# Altium schematic sheet SoC.SchDoc(SoC)
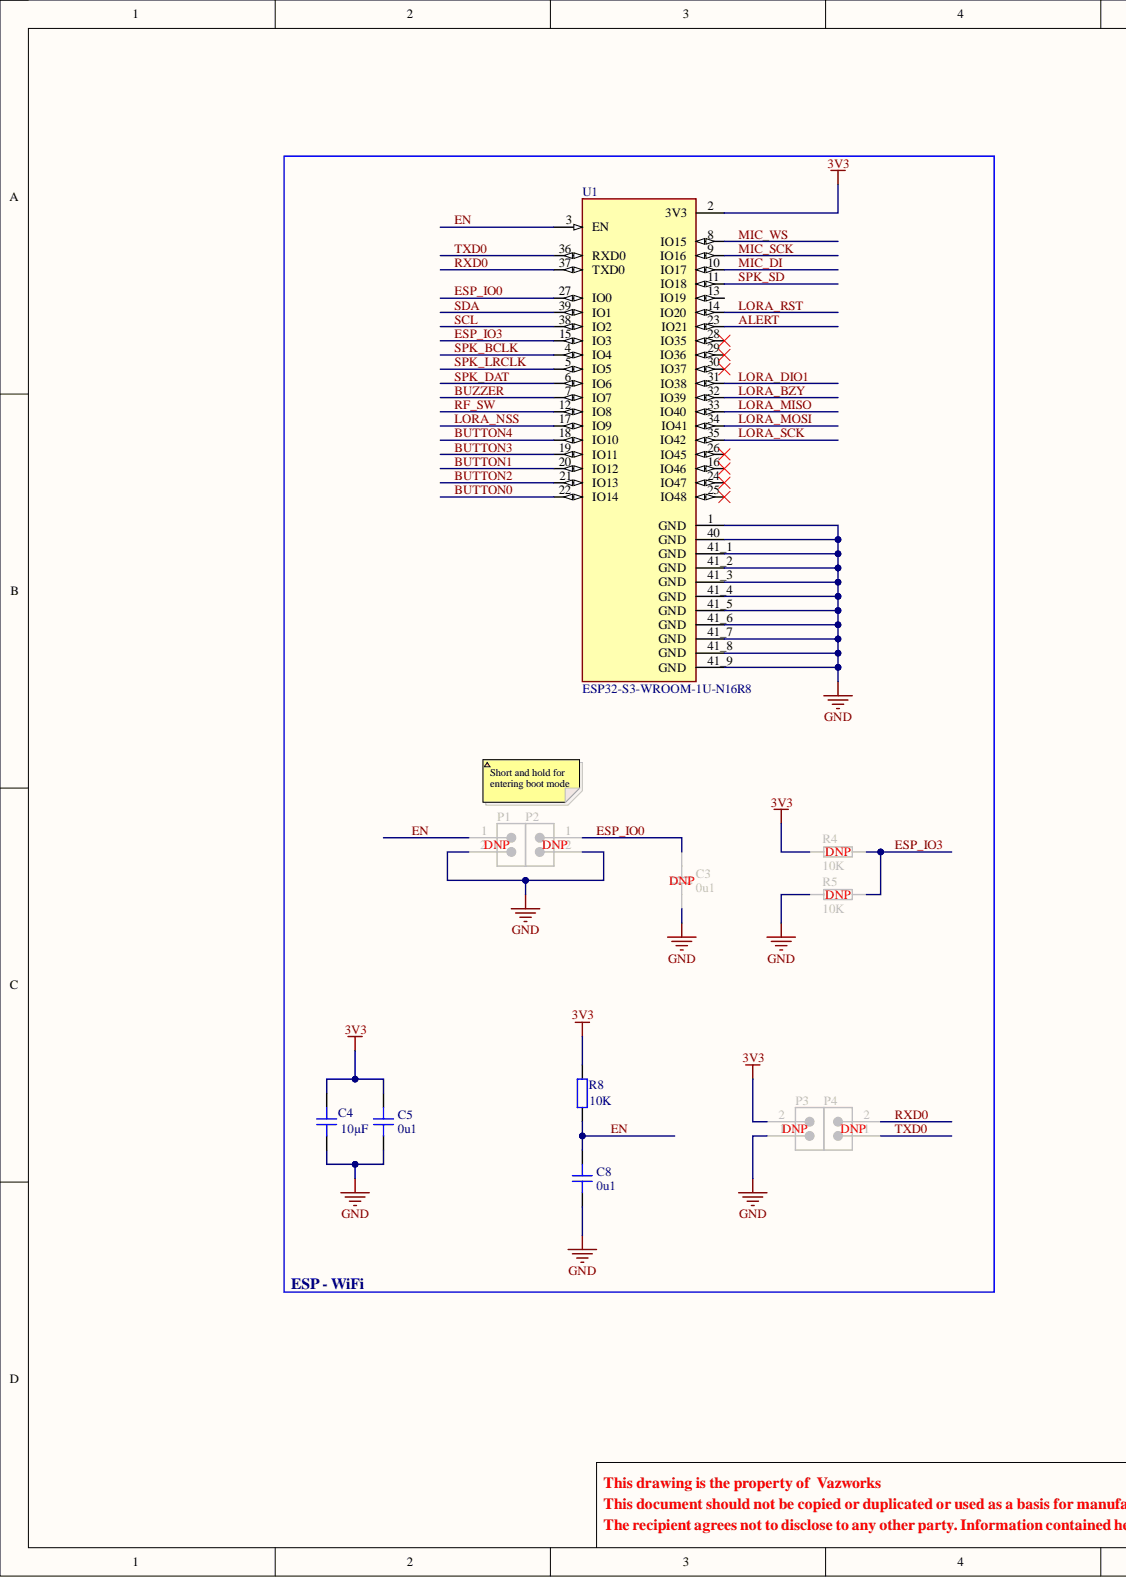
[diagram p0: SoC.SchDoc, left]
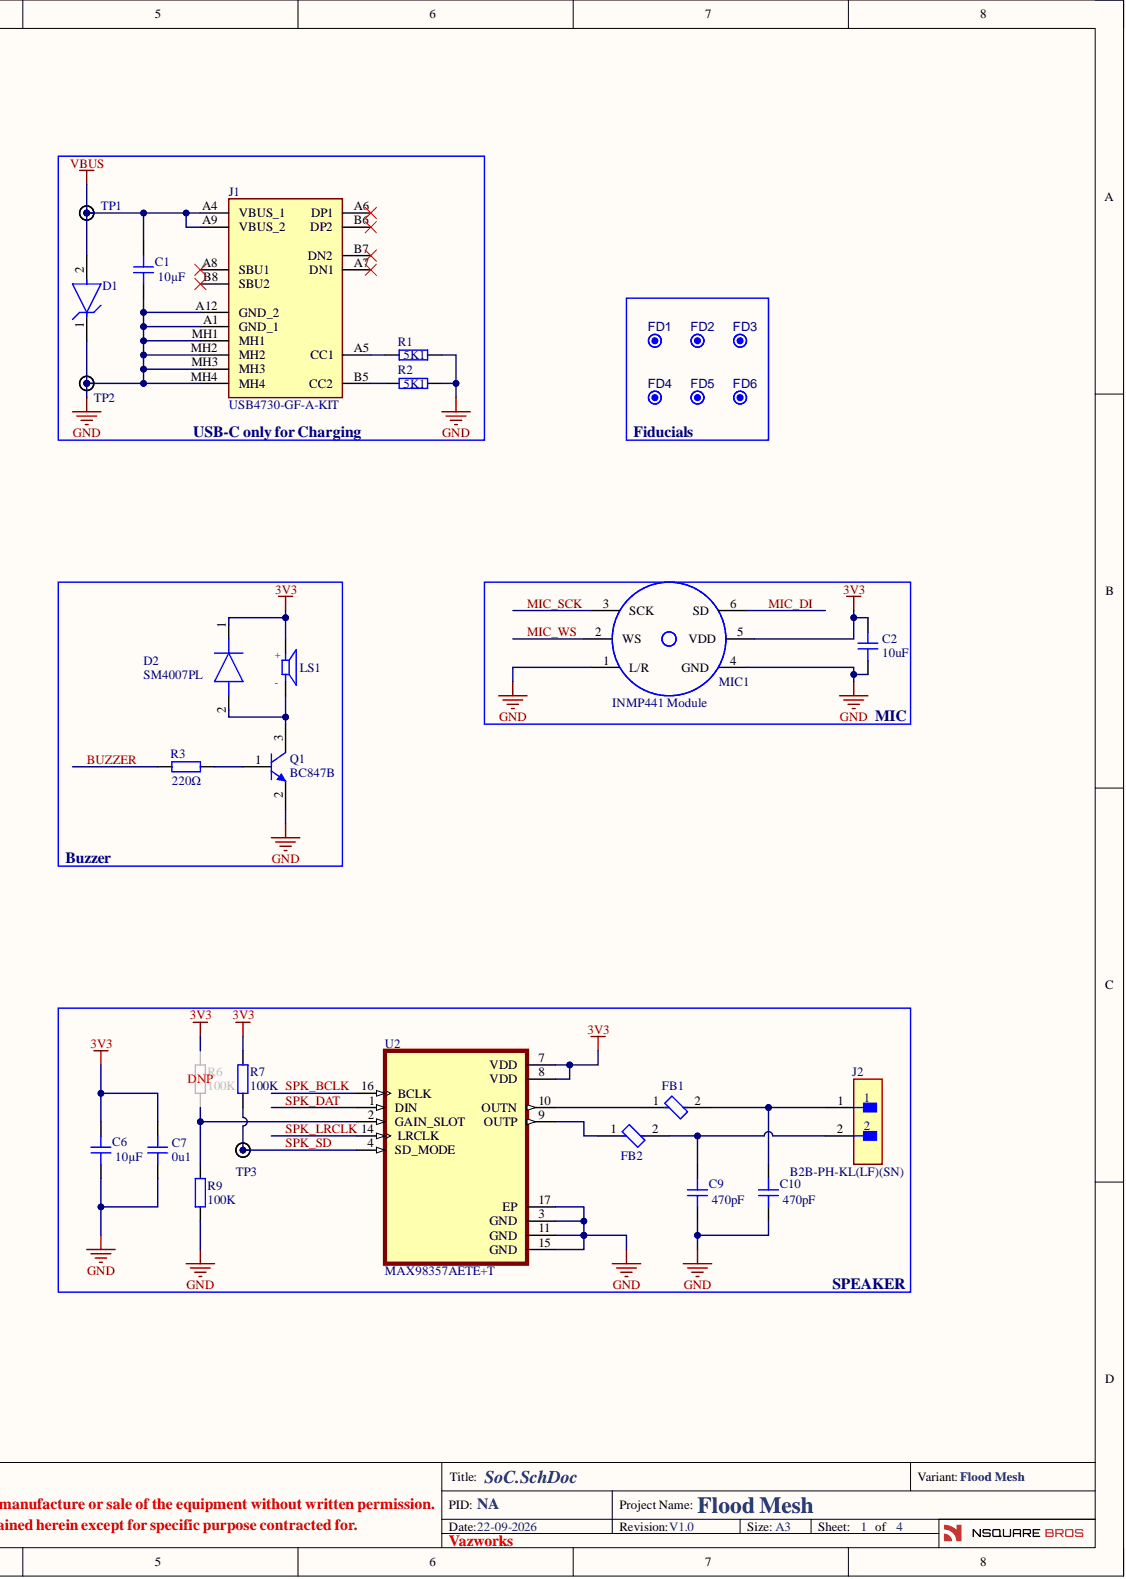
[diagram p1: SoC.SchDoc, right]

## Parts
C1  value 10µF  [CAP_0603]  (hidden comment: CL10A106KP8NNNC)
C2  value 10uF  [CAP_0603]  (hidden comment: GRM188R60J106ME47D)
C3  value 0u1  [CAP_0402]  (hidden comment: CC0402JRX7R8BB104)
C4  value 10µF  [CAP_0603]  (hidden comment: CL10A106KP8NNNC)
C5  value 0u1  [CAP_0402]  (hidden comment: CC0402JRX7R8BB104)
C6  value 10µF  [CAP_0603]  (hidden comment: CL10A106KP8NNNC)
C7  value 0u1  [CAP_0402]  (hidden comment: CC0402JRX7R8BB104)
C8  value 0u1  [CAP_0402]  (hidden comment: CC0402JRX7R8BB104)
C9  value 470pF  [CAP_0603]  (hidden comment: C0603C471J5RACAUTO)
C10  value 470pF  [CAP_0603]  (hidden comment: C0603C471J5RACAUTO)
D1  ESDA7P60-1U1M  [FP-1610-MFG]  (value not drawn)
D2  SM4007PL  [SODFL3718X125N]
FB1  MPZ2012S300ATD25  [FP-MPZ2012-IPC_B]  (value not drawn)
FB2  MPZ2012S300ATD25  [FP-MPZ2012-IPC_B]  (value not drawn)
FD1  FID1X2R  [FID1X2R]  (value not drawn)  (no BOM)
FD2  FID1X2R  [FID1X2R]  (value not drawn)  (no BOM)
FD3  FID1X2R  [FID1X2R]  (value not drawn)  (no BOM)
FD4  FID1X2R  [FID1X2R]  (value not drawn)  (no BOM)
FD5  FID1X2R  [FID1X2R]  (value not drawn)  (no BOM)
FD6  FID1X2R  [FID1X2R]  (value not drawn)  (no BOM)
J1  USB4730-GF-A-KIT  [USB4730GFAKIT]
J2  B2B-PH-KL(LF)(SN)  [FP-B2B-PH-KL_LF_SN-MFG]
LS1  CMT-0904-85T  [XDCR_CMT-0904-85T]  (value not drawn)
MIC1  INMP441 Module  [INMP441]
P1  62000211121  [62000211121]  (value not drawn)
P2  62000211121  [62000211121]  (value not drawn)
P3  62000211121  [62000211121]  (value not drawn)
P4  62000211121  [62000211121]  (value not drawn)
Q1  BC847B  [INF-SOT23_M]
R1  value 5K1  [RES_0603]  (hidden comment: AC0603FR-7W5K1L)
R2  value 5K1  [RES_0603]  (hidden comment: AC0603FR-7W5K1L)
R3  value 220Ω  [RES_0402]  (hidden comment: 0402WGF2200TCE)
R4  value 10K  [RES_0402]  (hidden comment: AC0402FR-7W10KL)
R5  value 10K  [RES_0402]  (hidden comment: AC0402FR-7W10KL)
R6  value 100K  [RES_0402]  (hidden comment: CRCW0402100KFKEDHP)
R7  value 100K  [RES_0402]  (hidden comment: CRCW0402100KFKEDHP)
R8  value 10K  [RES_0402]  (hidden comment: AC0402FR-7W10KL)
R9  value 100K  [RES_0402]  (hidden comment: CRCW0402100KFKEDHP)
TP1  TP_NOBOM  [PAD_RND_1MM]  (value not drawn)  (no BOM)
TP2  TP_NOBOM  [PAD_RND_1MM]  (value not drawn)  (no BOM)
TP3  TP_NOBOM  [PAD_RND_1MM]  (value not drawn)  (no BOM)
U1  ESP32-S3-WROOM-1U-N16R8  [XCVR_ESP32-S3-WROOM-1U-N16R8]
U2  MAX98357AETE+T  [QFN50P300X300X80-17N]

## Nets
3V3: C2.2 C4.2 C5.2 C6.2 C7.2 D2.1 LS1.P MIC1.5(VDD) P3.2 R4.1 R6.2 R7.2 R8.1 U1.2(3V3) U2.7(VDD) U2.8(VDD)
GND: C1.1 C2.1 C3.1 C4.1 C5.1 C6.1 C7.1 C8.1 C9.1 C10.1 D1.1 J1.A1(GND_1) J1.A12(GND_2) J1.MH1 J1.MH2 J1.MH3 J1.MH4 MIC1.1(L/R) MIC1.4(GND) P1.2 P2.2 P3.1 Q1.2 R1.2 R2.2 R5.1 R9.1 TP2.1 U1.1(GND) U1.40(GND) U1.41_1(GND) U1.41_2(GND) U1.41_3(GND) U1.41_4(GND) U1.41_5(GND) U1.41_6(GND) U1.41_7(GND) U1.41_8(GND) U1.41_9(GND) U2.3(GND) U2.11(GND) U2.15(GND) U2.17(EP)
VBUS: C1.2 D1.2 J1.A4(VBUS_1) J1.A9(VBUS_2) TP1.1
ALERT: U1.23(IO21)
BUTTON0: U1.22(IO14)
BUTTON1: U1.20(IO12)
BUTTON2: U1.21(IO13)
BUTTON3: U1.19(IO11)
BUTTON4: U1.18(IO10)
BUZZER: R3.1 U1.7(IO7)
EN: C8.2 P1.1 R8.2 U1.3(EN)
ESP_IO0: C3.2 P2.1 U1.27(IO0)
ESP_IO3: R4.2 R5.2 U1.15(IO3)
LORA_BZY: U1.32(IO39)
LORA_DIO1: U1.31(IO38)
LORA_MISO: U1.33(IO40)
LORA_MOSI: U1.34(IO41)
LORA_NSS: U1.17(IO9)
LORA_RST: U1.14(IO20)
LORA_SCK: U1.35(IO42)
MIC_DI: MIC1.6(SD) U1.10(IO17)
MIC_SCK: MIC1.3(SCK) U1.9(IO16)
MIC_WS: MIC1.2(WS) U1.8(IO15)
RF_SW: U1.12(IO8)
RXD0: P4.2 U1.37(TXD0)
SCL: U1.38(IO2)
SDA: U1.39(IO1)
SPK_BCLK: U1.4(IO4) U2.16(BCLK)
SPK_DAT: U1.6(IO6) U2.1(DIN)
SPK_LRCLK: U1.5(IO5) U2.14(LRCLK)
SPK_SD: R7.1 TP3.1 U1.11(IO18) U2.4(SD_MODE)
TXD0: P4.1 U1.36(RXD0)
NetC9_2: C9.2 FB2.2 J2.2
NetC10_2: C10.2 FB1.2 J2.1
NetD2_2: D2.2 LS1.N Q1.3
NetFB1_1: FB1.1 U2.10(OUTN)
NetFB2_1: FB2.1 U2.9(OUTP)
NetJ1_A5: J1.A5(CC1) R1.1
NetJ1_B5: J1.B5(CC2) R2.1
NetQ1_1: Q1.1 R3.2
NetR6_1: R6.1 R9.2 U2.2(GAIN_SLOT)

Not connected: J1 A6(DP1) A7(DN1) A8(SBU1) B6(DP2) B7(DN2) B8(SBU2); U1 13(IO19) 16(IO46) 24(IO47) 25(IO48) 26(IO45) 28(IO35) 29(IO36) 30(IO37)

## Library data of the parts
C1 C4 C6 = CAP_0603: 10V 10uF X5R ±10% 0603 Multilayer Ceramic Capacitors MLCC - SMD/SMT ROHS; Case Code (Imperial): 0603; Case Code (Metric): 1608; Case/Package: 0603; Category: Ceramic Capacitors; China RoHS: Compliant; Component Kind: Standard; ComponentLink1Description: https://datasheet.octopart.com:443/CL10A106KP8NNNC-Samsung-Electro-Mechanics-datasheet-181960519.pdf?src-supplier=Newark; ComponentLink1URL: https://datasheet.octopart.com:443/CL10A106KP8NNNC-Samsung-Electro-Mechanics-datasheet-181960519.pdf?src-supplier=Newark; ComponentLink2Description: https://datasheet.octopart.com/CL10A106KP8NNNC-Samsung-Electro-Mechanics-datasheet-8324245.pdf; ComponentLink2URL: https://datasheet.octopart.com/CL10A106KP8NNNC-Samsung-Electro-Mechanics-datasheet-8324245.pdf; ComponentLink3Description: https://datasheet.octopart.com/CL10A106KP8NNNC-Samsung-Electro-Mechanics-datasheet-97040617.pdf; ComponentLink3URL: https://datasheet.octopart.com/CL10A106KP8NNNC-Samsung-Electro-Mechanics-datasheet-97040617.pdf; ComponentLink4Description: https://datasheet.octopart.com:443/CL10A106KP8NNNC-Samsung-Electro-Mechanics-datasheet-136031754.pdf?src-supplier=Future+Electronics; ComponentLink4URL: https://datasheet.octopart.com:443/CL10A106KP8NNNC-Samsung-Electro-Mechanics-datasheet-136031754.pdf?src-supplier=Future+Electronics; ComponentLink5Description: https://datasheet.octopart.com:443/CL10A106KP8NNNC-Samsung-Electro-Mechanics-datasheet-110226289.pdf?src-supplier=Future+Electronics; ComponentLink5URL: https://datasheet.octopart.com:443/CL10A106KP8NNNC-Samsung-Electro-Mechanics-datasheet-110226289.pdf?src-supplier=Future+Electronics; ComponentLink6Description: https://datasheet.octopart.com:443/CL10A106KP8NNNC-Samsung-Electro-Mechanics-datasheet-81461787.pdf?src-supplier=Future+Electronics; ComponentLink6URL: https://datasheet.octopart.com:443/CL10A106KP8NNNC-Samsung-Electro-Mechanics-datasheet-81461787.pdf?src-supplier=Future+Electronics; ComponentLink7Description: https://datasheet.octopart.com:443/CL10A106KP8NNNC-Samsung-datasheet-48647232.pdf?src-supplier=Future+Electronics; ComponentLink7URL: https://datasheet.octopart.com:443/CL10A106KP8NNNC-Samsung-datasheet-48647232.pdf?src-supplier=Future+Electronics; ComponentLink8Description: https://datasheet.octopart.com:443/CL10A106KP8NNNC-Samsung-datasheet-34157262.pdf?src-supplier=Future+Electronics; ComponentLink8URL: https://datasheet.octopart.com:443/CL10A106KP8NNNC-Samsung-datasheet-34157262.pdf?src-supplier=Future+Electronics; ComponentLink9Description: https://datasheet.octopart.com:443/CL10A106KP8NNNC-Samsung-datasheet-26589120.pdf?src-supplier=Future+Electronics; ComponentLink9URL: https://datasheet.octopart.com:443/CL10A106KP8NNNC-Samsung-datasheet-26589120.pdf?src-supplier=Future+Electronics; ComponentLink10Description: https://datasheet.octopart.com:443/CL10A106KP8NNNC-Samsung-Electro-Mechanics-datasheet-149156481.pdf?src-supplier=Future+Electronics; ComponentLink10URL: https://datasheet.octopart.com:443/CL10A106KP8NNNC-Samsung-Electro-Mechanics-datasheet-149156481.pdf?src-supplier=Future+Electronics; ComponentLink11Description: https://datasheet.octopart.com:443/CL10A106KP8NNNC-Samsung-Electro-Mechanics-datasheet-11968064.pdf?src-supplier=Arrow+Electronics; ComponentLink11URL: https://datasheet.octopart.com:443/CL10A106KP8NNNC-Samsung-Electro-Mechanics-datasheet-11968064.pdf?src-supplier=Arrow+Electronics; ComponentLink12Description: https://datasheet.octopart.com:443/CL10A106KP8NNNC-Samsung-datasheet-8326407.pdf?src-supplier=TME; ComponentLink12URL: https://datasheet.octopart.com:443/CL10A106KP8NNNC-Samsung-datasheet-8326407.pdf?src-supplier=TME; Contact Plating: Tin; Country of Origin: Mainland China, Philippines, South Korea; Depth: 800µm; Dielectric Material: Ceramic; Export Control Classification Number (ECCN) Code: EAR99; Harmonized Tariff Schedule (HTS) Code: 8532.24.00.20; Height: 800µm; Introduction Date: 2009-10-27; Lead Free: Lead Free; Length: 1.6mm; Lifecycle Status: Production; Material: Ceramic; Max Operating Temperature: 85°C; Min Operating Temperature: -55°C; Mounting Technology: Surface Mount; Number of Terminals: 2; Pricing: 4000=0.01797, 8000=0.01761, 16000=0.01726, 32000=0.01693, 64000=0.01661 (USD); Radiation Hardening: No; REACH SVHC: Yes; RoHS: Compliant; Schedule B: 8532240020, 8532240020|8532240020|8532240020|8532240020|8532240020|8532240020|8532240020|8532240020|8532240020|8532240020|8532240020|8532240020|8532240020|8532240020|8532240020|8532240020|8532240020|8532240020|8532240020|8532240020|8532240020|8532240020|8532240020|85; Stock: 896000; Supplier: Avnet; Supplier Part Number: CL10A106KP8NNNC; Thickness: 889µm; Tolerance: 10%; Voltage Rating: 10V; Voltage Rating (DC): 10V; Width: 800µm; Library Name: Schematic-Lib-PhilsLab.SchLib
C2 = CAP_0603: Ceramic Capacitor, Multilayer, Ceramic, 6.3V, 20% +Tol, 20% -Tol, X5R, 15% TC, 10uF, Surface Mount, 0603; Multilayer: Yes; Capacitor Type: Ceramic; Case/Package: 0603; Category: Ceramic Capacitors; Component Kind: Standard; ComponentLink1Description: https://datasheet.ciiva.com:443/pdfs/VipMasterIC/IC/MURE/MURE-S-A0008911298/MURE-S-A0008911290-1.pdf?src-supplier=IHS+Markit; ComponentLink1URL: https://datasheet.ciiva.com:443/pdfs/VipMasterIC/IC/MURE/MURE-S-A0008911298/MURE-S-A0008911290-1.pdf?src-supplier=IHS+Markit; ComponentLink2Description: https://datasheet.ciiva.com/28584/415295-28584232.pdf?src-supplier=Element14; ComponentLink2URL: https://datasheet.ciiva.com/28584/415295-28584232.pdf?src-supplier=Element14; ComponentLink3Description: https://datasheet.ciiva.com/3777/10175grm188r60j106me4723-3777268.pdf?src-supplier=Arrow+Electronics; ComponentLink3URL: https://datasheet.ciiva.com/3777/10175grm188r60j106me4723-3777268.pdf?src-supplier=Arrow+Electronics; ComponentLink4Description: https://datasheet.ciiva.com/22776/1747457-22776418.pdf?src-supplier=Farnell; ComponentLink4URL: https://datasheet.ciiva.com/22776/1747457-22776418.pdf?src-supplier=Farnell; ComponentLink5Description: https://datasheet.ciiva.com/29202/450781-da-01-de-vs-chip-kond-0603-10-0-uf-20pr-6-3v-x5r-29202806.pdf?src-supplier=Conrad; ComponentLink5URL: https://datasheet.ciiva.com/29202/450781-da-01-de-vs-chip-kond-0603-10-0-uf-20pr-6-3v-x5r-29202806.pdf?src-supplier=Conrad; ComponentLink6Description: https://datasheet.ciiva.com/29199/454401-da-01-en-vs-kondensator-0603-29199677.pdf?src-supplier=Conrad; ComponentLink6URL: https://datasheet.ciiva.com/29199/454401-da-01-en-vs-kondensator-0603-29199677.pdf?src-supplier=Conrad; ComponentLink7Description: https://datasheet.ciiva.com/138/partnumbering-e-01-138659.pdf?src-supplier=Digikey; ComponentLink7URL: https://datasheet.ciiva.com/138/partnumbering-e-01-138659.pdf?src-supplier=Digikey; ComponentLink8Description: https://datasheet.ciiva.com/138/partnumbering-e-01-138658.pdf?src-supplier=Digikey; ComponentLink8URL: https://datasheet.ciiva.com/138/partnumbering-e-01-138658.pdf?src-supplier=Digikey; ComponentLink9Description: https://datasheet.ciiva.com/16373/l0005e-16373580.pdf?src-supplier=Future+Electronics; ComponentLink9URL: https://datasheet.ciiva.com/16373/l0005e-16373580.pdf?src-supplier=Future+Electronics; ComponentLink10Description: https://datasheet.ciiva.com/14116/grmseries-highdielectrictype-eng-14116428.pdf?src-supplier=Distrelec; ComponentLink10URL: https://datasheet.ciiva.com/14116/grmseries-highdielectrictype-eng-14116428.pdf?src-supplier=Distrelec; ComponentLink11Description: https://datasheet.ciiva.com/138/partnumbering-e-01-138660.pdf?src-supplier=Digikey; ComponentLink11URL: https://datasheet.ciiva.com/138/partnumbering-e-01-138660.pdf?src-supplier=Digikey; Dielectric Material: Ceramic; Height: 0.8mm; JESD-609 Code: e3; Length: 1.6mm; Max Operating Temperature: 85°C; Min Operating Temperature: -55°C; Mounting Technology: Surface Mount; Package Shape: Rectangular; Package Style: SMTMeter; Packaging: Tape and Reel; Pins: 2; Pricing: 1=0.14, 10=0.067, 50=0.067, 100=0.041, 1000=0.03, 10000=0.023 (USD); Rated DC Voltage (URdc): 6.3V; Reach SVHC Compliant: Yes; RoHS: Yes; RoHS Compliant: Yes; Stock: 161800; Supplier: Mouser; Supplier Part Number: 81-GRM188R60J106ME47; Temperature Characteristics Code: X5R; Terminal Finish: Nickel; Termination: Wraparound; Tolerance  (fill in as +-): 20%; Tolerance (fill in as +-): 20%; Width: 0.8mm; Library Name: Schematic-Lib-PhilsLab.SchLib
C3 C5 C7 C8 = CAP_0402: 25V 100nF X7R ±5% 0402 Multilayer Ceramic Capacitors MLCC - SMD/SMT ROHS; Case/Package: 0402; Category: Ceramic Capacitors; China RoHS: Compliant; Component Kind: Standard; ComponentLink1Description: https://datasheet.octopart.com:443/CC0402JRX7R8BB104-Yageo-datasheet-177165144.pdf?src-supplier=IHS; ComponentLink1URL: https://datasheet.octopart.com:443/CC0402JRX7R8BB104-Yageo-datasheet-177165144.pdf?src-supplier=IHS; ComponentLink2Description: https://datasheet.octopart.com:443/CC0402JRX7R8BB104-Yageo-datasheet-166511530.pdf?src-supplier=Future+Electronics; ComponentLink2URL: https://datasheet.octopart.com:443/CC0402JRX7R8BB104-Yageo-datasheet-166511530.pdf?src-supplier=Future+Electronics; ComponentLink3Description: https://datasheet.octopart.com:443/CC0402JRX7R8BB104-Yageo-datasheet-137700082.pdf?src-supplier=Future+Electronics; ComponentLink3URL: https://datasheet.octopart.com:443/CC0402JRX7R8BB104-Yageo-datasheet-137700082.pdf?src-supplier=Future+Electronics; ComponentLink4Description: https://datasheet.octopart.com:443/CC0402JRX7R8BB104-Yageo-Group-datasheet-165992755.pdf?src-supplier=ODG+(Origin+Data+Global); ComponentLink4URL: https://datasheet.octopart.com:443/CC0402JRX7R8BB104-Yageo-Group-datasheet-165992755.pdf?src-supplier=ODG+(Origin+Data+Global); ComponentLink5Description: https://datasheet.octopart.com:443/CC0402JRX7R8BB104-Yageo-datasheet-151948686.pdf?src-supplier=Farnell; ComponentLink5URL: https://datasheet.octopart.com:443/CC0402JRX7R8BB104-Yageo-datasheet-151948686.pdf?src-supplier=Farnell; ComponentLink6Description: https://datasheet.octopart.com/CC0402JRX7R8BB104-Yageo-datasheet-10883670.pdf; ComponentLink6URL: https://datasheet.octopart.com/CC0402JRX7R8BB104-Yageo-datasheet-10883670.pdf; ComponentLink7Description: http://sigma.octopart.com:80/conflict_mineral_statement/Yageo-company-3.pdf?src-supplier=Compliance+Statements; ComponentLink7URL: http://sigma.octopart.com:80/conflict_mineral_statement/Yageo-company-3.pdf?src-supplier=Compliance+Statements; ComponentLink8Description: http://sigma.octopart.com:80/rohs_statement/Yageo-company-2.pdf?src-supplier=Compliance+Statements; ComponentLink8URL: http://sigma.octopart.com:80/rohs_statement/Yageo-company-2.pdf?src-supplier=Compliance+Statements; Country of Origin: Mainland China, Taiwan; Dielectric Material: Ceramic; Export Control Classification Number (ECCN) Code: EAR99; Halogen Free: Halogen Free; Harmonized Tariff Schedule (HTS) Code: 8532.24.00.20; Height: 500µm; Introduction Date: 2013-05-01; Lead Free: Lead Free; Length: 1mm; Lifecycle Status: Production; Max Operating Temperature: 125°C; Min Operating Temperature: -55°C; Mounting Technology: Surface Mount; Number of Terminals: 2; Pricing: 10000=0.0095, 20000=0.0086 (USD); REACH SVHC: Yes; RoHS: Compliant; Stock: 1050000; Supplier: Arrow Electronics; Supplier Part Number: CC0402JRX7R8BB104; Tolerance: 5%; Voltage Rating (DC): 25V; Width: 500µm; Library Name: Schematic-Lib-PhilsLab.SchLib
C9 C10 = CAP_0603: 50V 470pF X7R ±5% 0603 Multilayer Ceramic Capacitors MLCC - SMD/SMT ROHS; Temperature Coefficient: 30ppm/°C; Case/Package: 0603; Category: Ceramic Capacitors; China RoHS: Compliant; Component Kind: Standard; ComponentLink1Description: https://datasheet.octopart.com:443/C0603C471J5RACAUTO-KEMET-datasheet-178087915.pdf?src-supplier=IHS; ComponentLink1URL: https://datasheet.octopart.com:443/C0603C471J5RACAUTO-KEMET-datasheet-178087915.pdf?src-supplier=IHS; ComponentLink2Description: https://datasheet.octopart.com:443/C0603C471J5RACAUTO-KEMET-datasheet-137357764.pdf?src-supplier=Upverter; ComponentLink2URL: https://datasheet.octopart.com:443/C0603C471J5RACAUTO-KEMET-datasheet-137357764.pdf?src-supplier=Upverter; ComponentLink3Description: https://datasheet.octopart.com:443/C0603C471J5RACAUTO-KEMET-datasheet-190355043.pdf?src-supplier=ODG+(Origin+Data+Global); ComponentLink3URL: https://datasheet.octopart.com:443/C0603C471J5RACAUTO-KEMET-datasheet-190355043.pdf?src-supplier=ODG+(Origin+Data+Global); ComponentLink4Description: https://datasheet.octopart.com:443/C0603C471J5RACAUTO-KEMET-datasheet-170668681.pdf?src-supplier=Farnell; ComponentLink4URL: https://datasheet.octopart.com:443/C0603C471J5RACAUTO-KEMET-datasheet-170668681.pdf?src-supplier=Farnell; ComponentLink5Description: https://datasheet.octopart.com:443/C0603C471J5RACAUTO-KEMET-datasheet-153410967.pdf?src-supplier=Electro+Sonic; ComponentLink5URL: https://datasheet.octopart.com:443/C0603C471J5RACAUTO-KEMET-datasheet-153410967.pdf?src-supplier=Electro+Sonic; ComponentLink6Description: https://datasheet.octopart.com/C0603C471J5RACAUTO-KEMET-datasheet-84169754.pdf; ComponentLink6URL: https://datasheet.octopart.com/C0603C471J5RACAUTO-KEMET-datasheet-84169754.pdf; Dielectric Material: Ceramic; Height: 800µm; Length: 1.6mm; Lifecycle Status: Production; Material: Ceramic; Max Operating Temperature: 125°C; Min Operating Temperature: -55°C; Mounting Technology: Surface Mount; Number of Terminals: 2; Pricing: 1=0.2, 10=0.111, 50=0.0782, 100=0.0681, 500=0.0511 (USD); Ratings: AEC-Q200; REACH SVHC: Unaffected; RoHS: Compliant; Stock: 11564; Supplier: DigiKey; Supplier Part Number: 399-C0603C471J5RACAUTOCT-ND; Voltage Rating: 50V; Voltage Rating (DC): 50V; Width: 800µm; Library Name: Schematic-Lib-PhilsLab.SchLib
D1 = CMP-12225-000013-1: TVS DIODE 5V 11.6V 1610; Manufacturer: STMicroelectronics; Breakdown Voltage: 6.4V; Clamping Voltage: 10.2V; Direction: Unidirectional; Max Junction Temp: +150°C; Number Of Channels: 1; Peak Pulse Current: 60A; Peak Pulse Power: 700W; Standoff Height: 0mm; Automotive: No; Category: Diode; Component Kind: Standard; ComponentLink2Description: Datasheet; ComponentLink2URL: http://www.st.com/content/ccc/resource/technical/document/datasheet/44/e9/26/44/42/ee/4f/e5/DM00139972.pdf/files/DM00139972.pdf/jcr:content/translations/en.DM00139972.pdf; Device Class L1: Circuit Protection; Device Class L2: TVS Diodes; Device Class L3: unset; Digikey Description: TVS DIODE 5V 11.6V 1610; Height: 0.65mm; Lead Free: Yes; Library Name: Altium Content Vault; Manufacturer Part Number: ESDA7P60-1U1M; Octopart: https://octopart.com/esda7p60-1u1m-stmicroelectronics-52112702; Package: 1610; Reverse Standoff Voltage: 5V; RoHS: TRUE; Temperature: -55°C to +150°C; Temperature Range High: +150°C; Temperature Range Low: -55°C
D2 = SM4007PL: Diode; Manufacturer_Name: MDD; Component Kind: Standard; Datasheet Link: https://datasheet.lcsc.com/szlcsc/1903051030_MDD-Jiangsu-Yutai-Elec-SM4007PL_C64898.pdf; Height: 1.25mm; LCSC: C64898; Manufacturer_Part_Number: SM4007PL
FB1 FB2 = CMP-08257-000015-1: FERRITE BEAD 30 OHM 0805 1LN; DC Resistance (DCR): 10mR; Impedance: 30R; Max Current Rating: 6A; Number of Lines: 1; Test Frequency: 100MHz; Case Code (Imperial): 0805; Case Code (Metric): 2012; Case/Package: 0805; Component Kind: Standard; Height: 0.041inch; Length: 0.079inch; Library Name: Altium Content Vault; Max DC Current: 6A; Max Operating Temperature: 125°C; Min Operating Temperature: -55°C; Mount: Surface Mount; Package Quantity: 4000; Packaging: Tape and Reel; Ratings: AEC-Q200; RoHS Compliant: Yes; Termination: SMD/SMT; Weight: 0.000194oz; Width: 1.25mm
FD1-FD6 = CMP-00028-00005-2: Fiducial - Round 1x2mm; Pins: 0; Library Name: Pravin Kumar
J1 = USB4730-GF-A-KIT: Connector; Manufacturer_Name: GCT (GLOBAL CONNECTOR TECHNOLOGY); Component Kind: Standard; Datasheet Link: https://www.gct.co/files/drawings/USB4730.pdf; Height: 4.81mm; Library Name: SamacSys.SchLib; Manufacturer_Part_Number: USB4730-GF-A-KIT; Mouser Part Number: 640-USB4730GFAKIT; Mouser Price/Stock: https://www.mouser.co.uk/ProductDetail/GCT/USB4730-GF-A-KIT?qs=Z%252BL2brAPG1IoU%252BBwnQ%2FE8Q%3D%3D
J2 = CMP-17439-000682-1: Connector Header, PH Series, 2 Position, 2.00mm, Shrouded Through Hole, Press-Fit, 100V, 32-24 AWG, Solder, Gray; Number of Positions: 2; Pitch: 2mm; Component Kind: Standard; Connector Type: Header; Contact Finish - Mating: TIN; Contact Material: Brass; Contact Shape: Square; Contact Type: Male Pin; Fastening Type: Detent; Flammability Rating: UL94 V-0; Insulation Color: Grey; Insulation Material: Nylon; Lead Free: Lead Free; Library Name: Altium Content Vault; Mount: Through Hole; Number of Rows: 1; Packaging: Bulk; Series: PH; Termination: Press-Fit; Voltage Rating: 100V
LS1 = CMT-0904-85T: MF: {'manufacturer': u'CUI Devices'}; Availability: Unavailable; Component Kind: Standard; LCSC: C3811834; MP: CMT-0904-85T; Package: None; Price: None
MIC1 = INMP441: Component Kind: Standard; Library Name: Flood_Mesh.SchLib
P1-P4 = CMP-1502-00956-3: NexarPartId: 61924778; Contact Resistance: 20mΩ; Current Rating: 2A; Gender: Male; Number of Contacts: 2; Operating Supply Voltage: 200V; Component Kind: Standard; Contact Material: Gold; Height: 6.35mm; Lead Pitch: 2mm; Library Name: Altium Content Vault; Max Operating Temperature: 105°C; Min Operating Temperature: -40°C; Number of Pins: 2; Number of Rows: 1; Orientation: Vertical; RoHS: Non-Compliant; Voltage Rating: 200V
Q1 = CMP-1177-00042-1: NPN Silicon AF Transistor, 45 V VCEO, 100 mA IC, SOT23, Reel, Green; Component Kind: Standard; ComponentLink1Description: Manufacturer URL; ComponentLink1URL: http://www.infineon.com/; ComponentLink2Description: Datasheet; ComponentLink2URL: http://www.infineon.com/dgdl/bc847series_bc848series_bc849series_bc850series.pdf?folderId=db3a304314dca389011541d30fa21656&fileId=db3a304314dca389011541d4630a1657; ComponentLink3Description: Package Specification; ComponentLink3URL: http://www.infineon.com/dgdl/SOT23-Package_Overview.pdf?folderId=db3a30431936bc4b0119532290053c66&fileId=db3a30431936bc4b0119533468123c85; LCSC: C20069135; Library Name: Altium Content Vault
R1 R2 = RES_0603: Fixed Resistor, Metal Glaze/Thick Film, 0.2W, 5100ohm, 75V, 1% +/-Tol, Surface Mount, 0603; Power Rating: 200mW; Temperature Coefficient: 100ppm/°C; Case/Package: 0603; Category: Chip SMD Resistors; China RoHS: Non-Compliant; Component Kind: Standard; ComponentLink1Description: https://datasheet.octopart.com:443/AC0603FR-7W5K1L-Yageo-datasheet-182189377.pdf?src-supplier=IHS; ComponentLink1URL: https://datasheet.octopart.com:443/AC0603FR-7W5K1L-Yageo-datasheet-182189377.pdf?src-supplier=IHS; ComponentLink2Description: https://datasheet.octopart.com/AC0603FR-7W5K1L-Yageo-datasheet-12512238.pdf; ComponentLink2URL: https://datasheet.octopart.com/AC0603FR-7W5K1L-Yageo-datasheet-12512238.pdf; ComponentLink3Description: http://sigma.octopart.com:80/conflict_mineral_statement/Yageo-company-3.pdf?src-supplier=Compliance+Statements; ComponentLink3URL: http://sigma.octopart.com:80/conflict_mineral_statement/Yageo-company-3.pdf?src-supplier=Compliance+Statements; ComponentLink4Description: http://sigma.octopart.com:80/rohs_statement/Yageo-company-2.pdf?src-supplier=Compliance+Statements; ComponentLink4URL: http://sigma.octopart.com:80/rohs_statement/Yageo-company-2.pdf?src-supplier=Compliance+Statements; Country of Origin: Mainland China, Taiwan; Export Control Classification Number (ECCN) Code: EAR99; Harmonized Tariff Schedule (HTS) Code: 8533.21.00.30; Height: 450µm; Introduction Date: 2017-06-20; Length: 1.6mm; Lifecycle Status: Production; Max Operating Temperature: 155°C; Min Operating Temperature: -55°C; Mounting Technology: Surface Mount; Number of Terminals: 2; Packaging: Tape & Reel; Pricing: 1=0.1, 10=0.032, 25=0.024, 50=0.0196, 100=0.0161, 250=0.01264, 500=0.0107, 1000=0.00916 (USD); Ratings: AEC-Q200; REACH SVHC: Yes; RoHS: Compliant; Stock: 5000; Supplier: DigiKey; Supplier Part Number: 13-AC0603FR-7W5K1LCT-ND; Tolerance: 1%; Width: 800µm; Working Voltage: 75V; Library Name: Schematic-Lib-PhilsLab.SchLib
R3 = RES_0402: Res Thick Film 0402 220 Ohm 1% 0.063W(1/16W) ±100ppm/C Pad SMD; Temperature Coefficient: 100ppm/°C; Case/Package: 0402; Category: Chip SMD Resistors; Component Kind: Standard; ComponentLink1Description: https://datasheet.octopart.com:443/0402WGF2200TCE-Royal-Ohm-datasheet-165785611.pdf?src-supplier=TME; ComponentLink1URL: https://datasheet.octopart.com:443/0402WGF2200TCE-Royal-Ohm-datasheet-165785611.pdf?src-supplier=TME; ComponentLink2Description: https://datasheet.octopart.com:443/0402WGF2200TCE-Royal-Ohm-datasheet-157855877.pdf?src-supplier=TME; ComponentLink2URL: https://datasheet.octopart.com:443/0402WGF2200TCE-Royal-Ohm-datasheet-157855877.pdf?src-supplier=TME; ComponentLink3Description: https://datasheet.octopart.com:443/0402WGF2200TCE-Royal-Ohm-datasheet-62069544.pdf?src-supplier=TME; ComponentLink3URL: https://datasheet.octopart.com:443/0402WGF2200TCE-Royal-Ohm-datasheet-62069544.pdf?src-supplier=TME; ComponentLink4Description: https://datasheet.octopart.com:443/0402WGF2200TCE-Royal-Ohm-datasheet-17591902.pdf?src-supplier=TME; ComponentLink4URL: https://datasheet.octopart.com:443/0402WGF2200TCE-Royal-Ohm-datasheet-17591902.pdf?src-supplier=TME; Composition: Thick Film; LCSC: C25091; Pricing: 10000=0.004 (USD); Supplier: Mouser; Supplier Part Number: 303-0402WGF2200TCE; Tolerance: 1%; Library Name: Schematic-Lib-PhilsLab.SchLib
R4 R5 R8 = RES_0402: Res Thick Film 0402 10K Ohm 1% 0.125W(1/8W) ±100ppm/C Pad SMD Automotive T/R; Power Dissipation: 125mW; Temperature Coefficient: 100ppm/°C; Voltage Rating: 50V; Working Voltage: 75V; Case/Package: 0402; Category: Chip SMD Resistors; China RoHS: Non-Compliant; Component Kind: Standard; ComponentLink1Description: https://datasheet.octopart.com:443/AC0402FR-7W10KL-Yageo-datasheet-182189377.pdf?src-supplier=IHS; ComponentLink1URL: https://datasheet.octopart.com:443/AC0402FR-7W10KL-Yageo-datasheet-182189377.pdf?src-supplier=IHS; ComponentLink2Description: https://datasheet.octopart.com:443/AC0402FR-7W10KL-Yageo-datasheet-83209224.pdf?src-supplier=Upverter; ComponentLink2URL: https://datasheet.octopart.com:443/AC0402FR-7W10KL-Yageo-datasheet-83209224.pdf?src-supplier=Upverter; ComponentLink3Description: https://datasheet.octopart.com:443/AC0402FR-7W10KL-Yageo-datasheet-178509465.pdf?src-supplier=Farnell; ComponentLink3URL: https://datasheet.octopart.com:443/AC0402FR-7W10KL-Yageo-datasheet-178509465.pdf?src-supplier=Farnell; ComponentLink4Description: https://datasheet.octopart.com:443/AC0402FR-7W10KL-Yageo-datasheet-151884373.pdf?src-supplier=Future+Electronics; ComponentLink4URL: https://datasheet.octopart.com:443/AC0402FR-7W10KL-Yageo-datasheet-151884373.pdf?src-supplier=Future+Electronics; ComponentLink5Description: https://datasheet.octopart.com:443/AC0402FR-7W10KL-Yageo-Group-datasheet-189830439.pdf?src-supplier=Future+Electronics; ComponentLink5URL: https://datasheet.octopart.com:443/AC0402FR-7W10KL-Yageo-Group-datasheet-189830439.pdf?src-supplier=Future+Electronics; ComponentLink6Description: https://datasheet.octopart.com:443/AC0402FR-7W10KL-Yageo-datasheet-166720450.pdf?src-supplier=Future+Electronics; ComponentLink6URL: https://datasheet.octopart.com:443/AC0402FR-7W10KL-Yageo-datasheet-166720450.pdf?src-supplier=Future+Electronics; ComponentLink7Description: https://datasheet.octopart.com:443/AC0402FR-7W10KL-Yageo-Group-datasheet-164768995.pdf?src-supplier=ODG+(Origin+Data+Global); ComponentLink7URL: https://datasheet.octopart.com:443/AC0402FR-7W10KL-Yageo-Group-datasheet-164768995.pdf?src-supplier=ODG+(Origin+Data+Global); ComponentLink8Description: https://datasheet.octopart.com:443/AC0402FR-7W10KL-Yageo-Group-datasheet-159083007.pdf?src-supplier=HQonline; ComponentLink8URL: https://datasheet.octopart.com:443/AC0402FR-7W10KL-Yageo-Group-datasheet-159083007.pdf?src-supplier=HQonline; ComponentLink9Description: https://datasheet.octopart.com/AC0402FR-7W10KL-Yageo-datasheet-12512238.pdf; ComponentLink9URL: https://datasheet.octopart.com/AC0402FR-7W10KL-Yageo-datasheet-12512238.pdf; ComponentLink10Description: http://sigma.octopart.com:80/conflict_mineral_statement/Yageo-company-3.pdf?src-supplier=Compliance+Statements; ComponentLink10URL: http://sigma.octopart.com:80/conflict_mineral_statement/Yageo-company-3.pdf?src-supplier=Compliance+Statements; ComponentLink11Description: http://sigma.octopart.com:80/rohs_statement/Yageo-company-2.pdf?src-supplier=Compliance+Statements; ComponentLink11URL: http://sigma.octopart.com:80/rohs_statement/Yageo-company-2.pdf?src-supplier=Compliance+Statements; Composition: Thick Film; Height: 320µm; Lifecycle Status: Production; Max Operating Temperature: 155°C; Min Operating Temperature: -55°C; Mounting Technology: Surface Mount; Number of Terminals: 2; Packaging: Tape & Reel; Power Rating: 125mW; Pricing: 10000=0.002, 20000=0.00192, 40000=0.00186, 80000=0.00179, 160000=0.00173 (USD); REACH SVHC: Yes; RoHS: Compliant; Stock: 3430000; Supplier: Avnet; Supplier Part Number: AC0402FR-7W10KL; Tolerance: 1%; Width: 500µm; Library Name: Schematic-Lib-PhilsLab.SchLib
R6 R7 R9 = RES_0402: Res Thick Film 0402 100K Ohm 1% 0.2W(1/5W) ±100ppm/C Pad SMD Automotive T/R; Manufacturer Package Identifier: CRCW0402-HPe3; Max Power Dissipation: 125mW; Power Dissipation: 200mW; Temperature Coefficient: 100ppm/°C; Voltage Rating: 50V; Case Code (Imperial): 0402; Case Code (Metric): 1005; Case/Package: 0402; Category: Chip SMD Resistors; China RoHS: Non-Compliant; Component Kind: Standard; ComponentLink1Description: https://datasheet.octopart.com/CRCW0402100KFKEDHP-Vishay-datasheet-83567917.pdf; ComponentLink1URL: https://datasheet.octopart.com/CRCW0402100KFKEDHP-Vishay-datasheet-83567917.pdf; ComponentLink2Description: https://datasheet.octopart.com:443/CRCW0402100KFKEDHP-Vishay-datasheet-87136057.pdf?src-supplier=Newark; ComponentLink2URL: https://datasheet.octopart.com:443/CRCW0402100KFKEDHP-Vishay-datasheet-87136057.pdf?src-supplier=Newark; ComponentLink3Description: https://datasheet.octopart.com:443/CRCW0402100KFKEDHP-Vishay-datasheet-66581887.pdf?src-supplier=Newark; ComponentLink3URL: https://datasheet.octopart.com:443/CRCW0402100KFKEDHP-Vishay-datasheet-66581887.pdf?src-supplier=Newark; ComponentLink4Description: https://datasheet.octopart.com:443/CRCW0402100KFKEDHP-Vishay-datasheet-112276998.pdf?src-supplier=Newark; ComponentLink4URL: https://datasheet.octopart.com:443/CRCW0402100KFKEDHP-Vishay-datasheet-112276998.pdf?src-supplier=Newark; ComponentLink5Description: https://datasheet.octopart.com:443/CRCW0402100KFKEDHP-Vishay-datasheet-8359436.pdf?src-supplier=Newark; ComponentLink5URL: https://datasheet.octopart.com:443/CRCW0402100KFKEDHP-Vishay-datasheet-8359436.pdf?src-supplier=Newark; ComponentLink6Description: https://datasheet.octopart.com:443/CRCW0402100KFKEDHP-Vishay-datasheet-62317933.pdf?src-supplier=element14+APAC; ComponentLink6URL: https://datasheet.octopart.com:443/CRCW0402100KFKEDHP-Vishay-datasheet-62317933.pdf?src-supplier=element14+APAC; ComponentLink7Description: https://datasheet.octopart.com:443/CRCW0402100KFKEDHP-Vishay-datasheet-37143532.pdf?src-supplier=Farnell; ComponentLink7URL: https://datasheet.octopart.com:443/CRCW0402100KFKEDHP-Vishay-datasheet-37143532.pdf?src-supplier=Farnell; ComponentLink8Description: https://datasheet.octopart.com:443/CRCW0402100KFKEDHP-Vishay-Draloric-datasheet-15501475.pdf?src-supplier=Farnell; ComponentLink8URL: https://datasheet.octopart.com:443/CRCW0402100KFKEDHP-Vishay-Draloric-datasheet-15501475.pdf?src-supplier=Farnell; ComponentLink9Description: https://datasheet.octopart.com:443/CRCW0402100KFKEDHP-Vishay-datasheet-62316122.pdf?src-supplier=Future+Electronics; ComponentLink9URL: https://datasheet.octopart.com:443/CRCW0402100KFKEDHP-Vishay-datasheet-62316122.pdf?src-supplier=Future+Electronics; ComponentLink10Description: https://datasheet.octopart.com:443/CRCW0402100KFKEDHP-Vishay-datasheet-13148866.pdf?src-supplier=Future+Electronics; ComponentLink10URL: https://datasheet.octopart.com:443/CRCW0402100KFKEDHP-Vishay-datasheet-13148866.pdf?src-supplier=Future+Electronics; ComponentLink11Description: https://datasheet.octopart.com:443/CRCW0402100KFKEDHP-Vishay-datasheet-13131392.pdf?src-supplier=Future+Electronics; ComponentLink11URL: https://datasheet.octopart.com:443/CRCW0402100KFKEDHP-Vishay-datasheet-13131392.pdf?src-supplier=Future+Electronics; ComponentLink12Description: https://datasheet.octopart.com:443/CRCW0402100KFKEDHP-Vishay-datasheet-12593106.pdf?src-supplier=Future+Electronics; ComponentLink12URL: https://datasheet.octopart.com:443/CRCW0402100KFKEDHP-Vishay-datasheet-12593106.pdf?src-supplier=Future+Electronics; ComponentLink13Description: https://datasheet.octopart.com:443/CRCW0402100KFKEDHP-Vishay-datasheet-12544674.pdf?src-supplier=Future+Electronics; ComponentLink13URL: https://datasheet.octopart.com:443/CRCW0402100KFKEDHP-Vishay-datasheet-12544674.pdf?src-supplier=Future+Electronics; ComponentLink14Description: https://datasheet.octopart.com:443/CRCW0402100KFKEDHP-Vishay-datasheet-12531795.pdf?src-supplier=Future+Electronics; ComponentLink14URL: https://datasheet.octopart.com:443/CRCW0402100KFKEDHP-Vishay-datasheet-12531795.pdf?src-supplier=Future+Electronics; ComponentLink15Description: https://datasheet.octopart.com:443/CRCW0402100KFKEDHP-Vishay-datasheet-12518686.pdf?src-supplier=Future+Electronics; ComponentLink15URL: https://datasheet.octopart.com:443/CRCW0402100KFKEDHP-Vishay-datasheet-12518686.pdf?src-supplier=Future+Electronics; ComponentLink16Description: https://datasheet.octopart.com:443/CRCW0402100KFKEDHP-Vishay-datasheet-12509906.pdf?src-supplier=Future+Electronics; ComponentLink16URL: https://datasheet.octopart.com:443/CRCW0402100KFKEDHP-Vishay-datasheet-12509906.pdf?src-supplier=Future+Electronics; ComponentLink17Description: https://datasheet.octopart.com:443/CRCW0402100KFKEDHP-Vishay-datasheet-10669844.pdf?src-supplier=Future+Electronics; ComponentLink17URL: https://datasheet.octopart.com:443/CRCW0402100KFKEDHP-Vishay-datasheet-10669844.pdf?src-supplier=Future+Electronics; ComponentLink18Description: https://datasheet.octopart.com:443/CRCW0402100KFKEDHP-Vishay-datasheet-8703221.pdf?src-supplier=Future+Electronics; ComponentLink18URL: https://datasheet.octopart.com:443/CRCW0402100KFKEDHP-Vishay-datasheet-8703221.pdf?src-supplier=Future+Electronics; ComponentLink19Description: https://datasheet.octopart.com:443/CRCW0402100KFKEDHP-Vishay-Dale-datasheet-47688881.pdf?src-supplier=RS+(Formerly+Allied+Electronics); ComponentLink19URL: https://datasheet.octopart.com:443/CRCW0402100KFKEDHP-Vishay-Dale-datasheet-47688881.pdf?src-supplier=RS+(Formerly+Allied+Electronics); ComponentLink20Description: http://sigma.octopart.com:80/rohs_statement/Vishay-company-15.pdf?src-supplier=Compliance+Statements; ComponentLink20URL: http://sigma.octopart.com:80/rohs_statement/Vishay-company-15.pdf?src-supplier=Compliance+Statements; Composition: Thick Film; Contact Plating: Tin; Depth: 500µm; Features: Automotive AEC-Q200; Height: 300µm; Lead Free: Lead Free; Length: 1mm; Lifecycle Status: Production; Max Operating Temperature: 155°C; Min Operating Temperature: -55°C; Mounting Technology: Surface Mount; Number of Terminals: 2; Number of Terminations: 2; Packaging: Cut Tape; Power Rating: 200mW; Pricing: 10000=0.0117 (USD); Radiation Hardening: No; REACH SVHC: Yes; RoHS: Compliant; Schedule B: 8533210045, 8533210045|8533210045|8533210045|8533210045|8533210045; Stock: 50000; Supplier: Arrow Electronics; Supplier Part Number: CRCW0402100KFKEDHP; Termination: SMD/SMT; Tolerance: 1%; Voltage Rating (DC): 50V; Weight: 652.039032µg; Width: 500µm; Working Voltage: 50V; Library Name: Schematic-Lib-PhilsLab.SchLib
TP1-TP3 = TEST_POINT_RND_1MM: Test Point Round 1mm (NO BOM); Library Name: Schematic-Lib-PhilsLab.SchLib
U1 = ESP32-S3-WROOM-1U-N16R8: MF: {'manufacturer': u'Espressif Systems'}; Availability: Unavailable; Component Kind: Standard; MP: ESP32-S3-WROOM-1U-N16R8; Package: None; Price: None
U2 = MAX98357AETE+T: Tiny, Low-Cost, PCM Class D Amplifier with Class AB Performance; MF: Analog Devices; Check_prices: https://www.snapeda.com/parts/MAX98357AETE+T/Analog+Devices/view-part/?ref=eda; Component Kind: Standard; Library Name: MAX98357AETE_T.IntLib; MP: MAX98357AETE+T; Package: TQFN-16 Maxim; SnapEDA_Link: https://www.snapeda.com/parts/MAX98357AETE+T/Analog+Devices/view-part/?ref=snap
